# Altium schematic sheet [6]Power.SchDoc
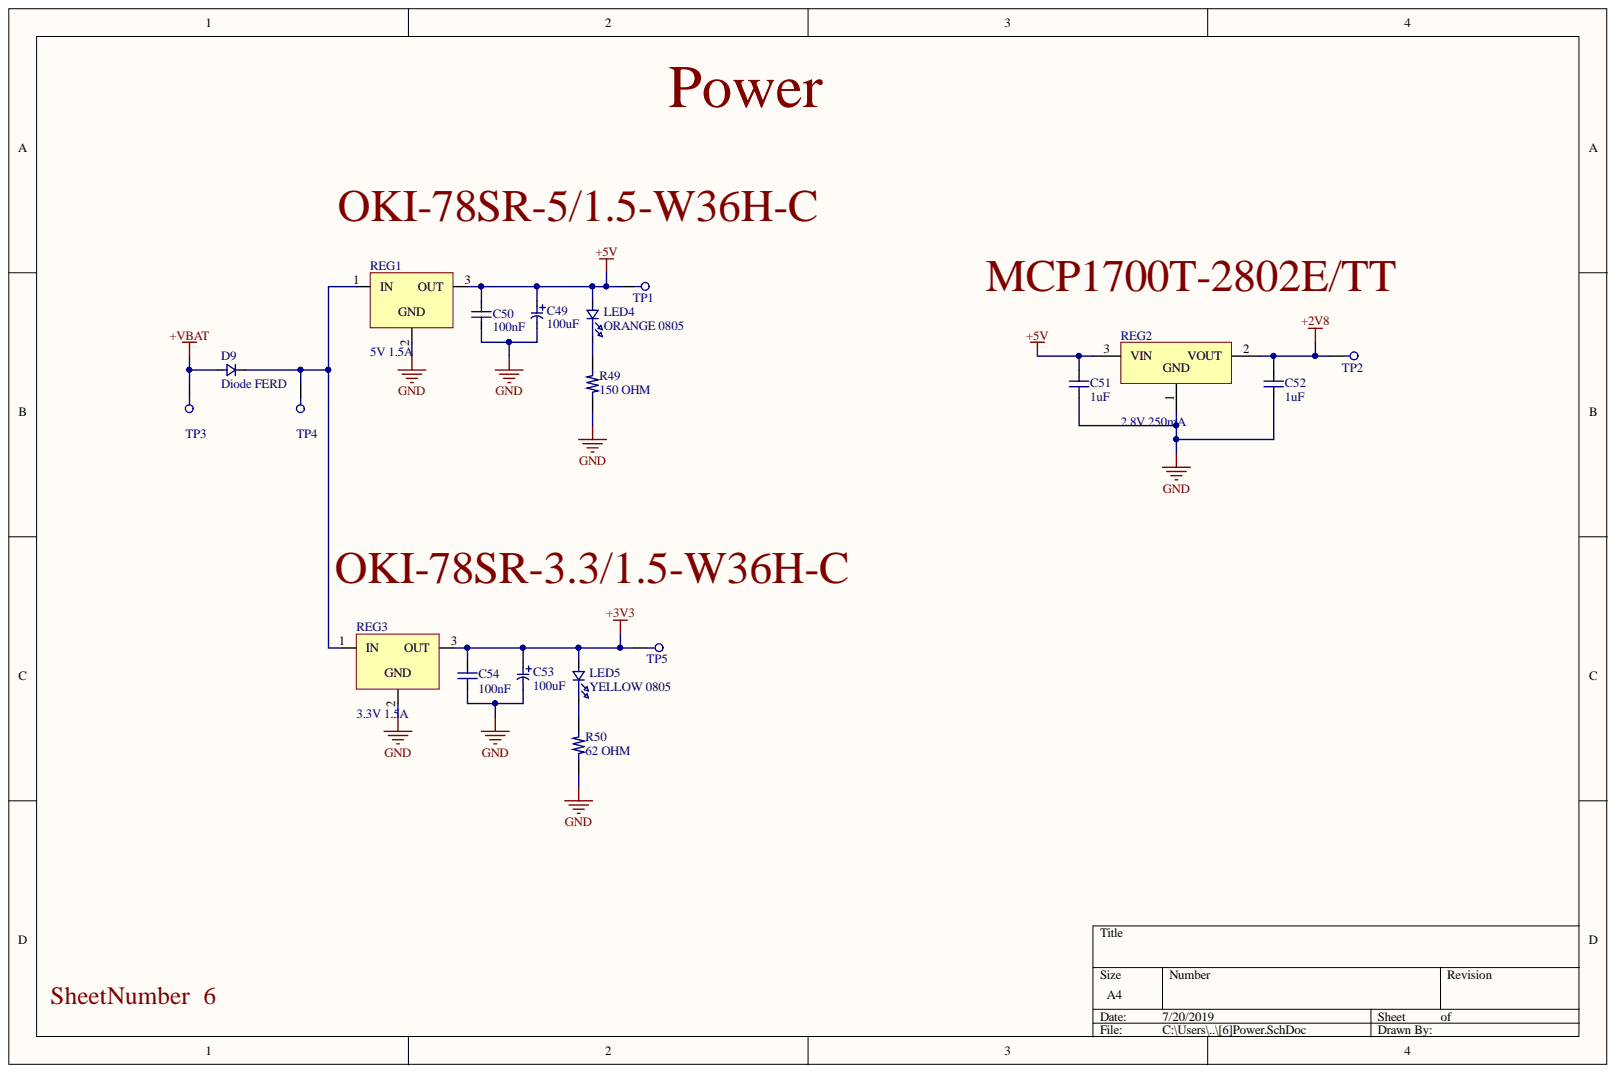
[diagram p0: [6]Power.SchDoc]

## Parts
C49  100uF  [Radial SMD 6.3D]
C50  100nF  [C0805]
C51  1uF  [C0805]
C52  1uF  [C0805]
C53  100uF  [Radial SMD 6.3D]
C54  100nF  [C0805]
D9  Diode FERD  [DPAK]
LED4  ORANGE 0805  [LED0805]
LED5  YELLOW 0805  [LED0805]
R49  150 OHM  [R0805]
R50  62 OHM  [R0805]
REG1  5V 1.5A  [3-SIP Module]
REG2  2.8V 250mA  [SOT23-3]
REG3  3.3V 1.5A  [3-SIP Module]
TP1  Test Point  [Test Point 1.2]  (value not drawn)
TP2  Test Point  [Test Point 1.2]  (value not drawn)
TP3  Test Point  [Test Point 1.2]  (value not drawn)
TP4  Test Point  [Test Point 1.2]  (value not drawn)
TP5  Test Point  [Test Point 1.2]  (value not drawn)

## Nets
+2V8: C52.2 REG2.2 TP2.1
+3V3: C53.1 C54.2 LED5.A REG3.3 TP5.1
+5V: C49.1 C50.2 C51.2 LED4.A REG1.3 REG2.3 TP1.1
+VBAT: D9.A TP3.1
GND: C49.2 C50.1 C51.1 C52.1 C53.2 C54.1 R49.1 R50.1 REG1.2 REG2.1 REG3.2
NetD9_K: D9.K REG1.1 REG3.1 TP4.1
NetLED4_K: LED4.K R49.2
NetLED5_K: LED5.K R50.2

## Library data of the parts
C49 C53 = EEV107M035A9HAA: CAP ALUM 100UF 20% 35V SMD; Mfr 1: KEMET; Component Kind: Standard; Library Name: Components.SchLib; Mfr 1 PN: EEV107M035A9HAA
C50 C54 = CC0805ZRY5V9BB104: CAP CER 0.1UF 50V Y5V 0805; Mfr 1: Yageo; Component Kind: Standard; Library Name: Components.SchLib; Mfr 1 PN: CC0805ZRY5V9BB104
C51 C52 = UMK212BJ105KG-T: CAP CER 1UF 50V X5R 0805; Mfr 1: Taiyo Yuden; Component Kind: Standard; Library Name: Components.SchLib; Mfr 1 PN: UMK212BJ105KG-T
D9 = FERD30H100SB-TR: DIODE RECT 100V 30A DPAK; Mfr 1: STMicroelectronics; Component Kind: Standard; Library Name: Components.SchLib; Mfr 1 PN: FERD30H100SB-TR
LED4 = APT2012SECK: LED ORANGE CLEAR CHIP SMD; Mfr 1: Kingbright; Component Kind: Standard; Library Name: Components.SchLib; Mfr 1 PN: APT2012SECK
LED5 = APT2012YC: LED YELLOW CLEAR CHIP SMD; Mfr 1: Kingbright; Component Kind: Standard; Library Name: Components.SchLib; Mfr 1 PN: APT2012YC
R49 = CR0805-JW-151ELF: RES SMD 150 OHM 5% 1/8W 0805; Mfr 1: Bourns Inc.; Component Kind: Standard; Library Name: Components.SchLib; Mfr 1 PN: CR0805-JW-151ELF
R50 = RR1220Q-620-D: RES SMD 62 OHM 0.5% 1/10W 0805; Mfr 1: Susumu; Component Kind: Standard; Library Name: Components.SchLib; Mfr 1 PN: RR1220Q-620-D
REG1 = OKI-78SR-5/1.5-W36H-C: DC DC CONVERTER 5V 8W; Mfr 1: Murata Power Solutions Inc.; Component Kind: Standard; Library Name: Components.SchLib; Mfr 1 PN: OKI-78SR-5/1.5-W36H-C
REG2 = MCP1700T-2802E/TT: IC REG LINEAR 2.8V 250MA SOT23-3; Mfr 1: Microchip Technology; Component Kind: Standard; Library Name: Components.SchLib; Mfr 1 PN: MCP1700T-2802E/TT
REG3 = OKI-78SR-3.3/1.5-W36H-C: DC DC CONVERTER 3.3V 5W; Mfr 1: Murata Power Solutions Inc.; Component Kind: Standard; Library Name: Components.SchLib; Mfr 1 PN: OKI-78SR-3.3/1.5-W36H-C
TP1-TP5 = Test Point: Component Kind: Standard; Description: Test Point; Library Name: Components.SchLib
